# Altium schematic sheet Schematic.SchDoc
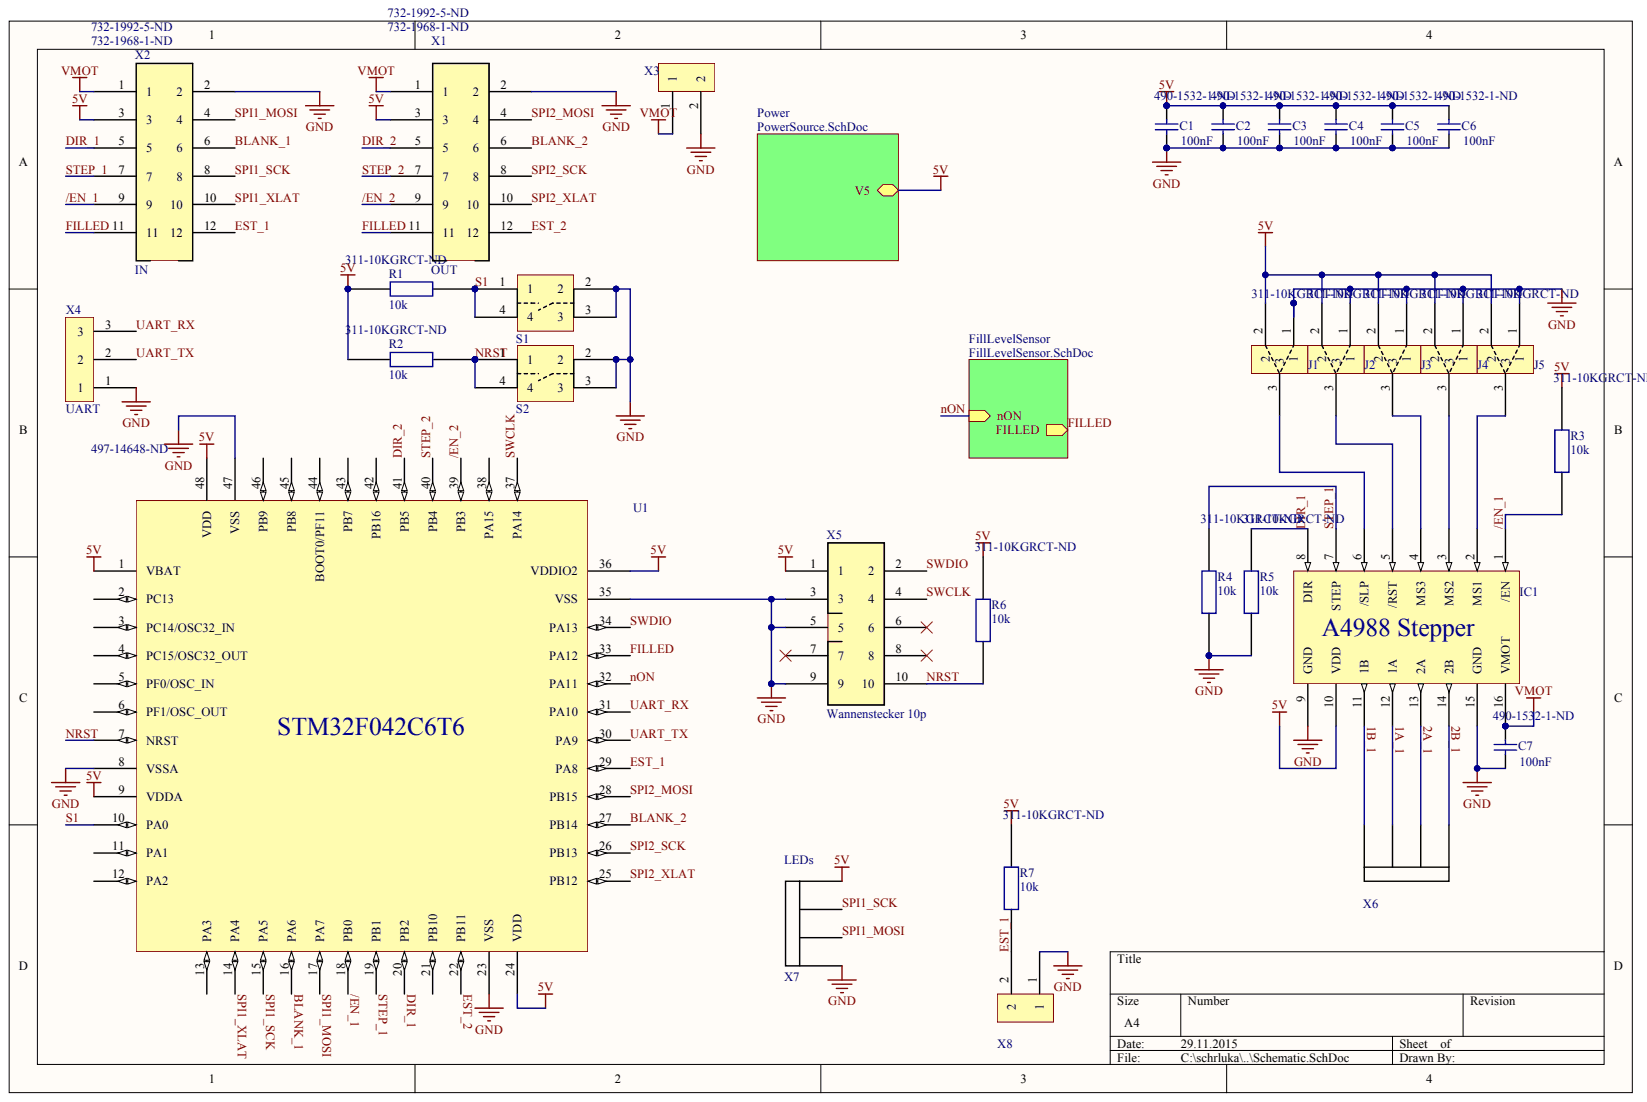
[diagram p0: Schematic.SchDoc]

## Parts
C1  value 100nF  Supplier Part Number 1: 490-1532-1-ND  (hidden comment: Cap)
C2  value 100nF  Supplier Part Number 1: 490-1532-1-ND  (hidden comment: Cap)
C3  value 100nF  Supplier Part Number 1: 490-1532-1-ND  (hidden comment: Cap)
C4  value 100nF  Supplier Part Number 1: 490-1532-1-ND  (hidden comment: Cap)
C5  value 100nF  Supplier Part Number 1: 490-1532-1-ND  (hidden comment: Cap)
C6  value 100nF  Supplier Part Number 1: 490-1532-1-ND  (hidden comment: Cap)
C7  value 100nF  Supplier Part Number 1: 490-1532-1-ND  (hidden comment: Cap)
IC1  A4988 Stepper
J1  Supplier Part Number 1: 311-10KGRCT-ND  (hidden comment: VCC to GND Jumper)
J2  Supplier Part Number 1: 311-10KGRCT-ND  (hidden comment: VCC to GND Jumper)
J3  Supplier Part Number 1: 311-10KGRCT-ND  (hidden comment: VCC to GND Jumper)
J4  Supplier Part Number 1: 311-10KGRCT-ND  (hidden comment: VCC to GND Jumper)
J5  Supplier Part Number 1: 311-10KGRCT-ND  (hidden comment: VCC to GND Jumper)
R1  Supplier Part Number 1: 311-10KGRCT-ND  (hidden comment: 10k)
R2  Supplier Part Number 1: 311-10KGRCT-ND  (hidden comment: 10k)
R3  Supplier Part Number 1: 311-10KGRCT-ND  (hidden comment: 10k)
R4  Supplier Part Number 1: 311-10KGRCT-ND  (hidden comment: 10k)
R5  Supplier Part Number 1: 311-10KGRCT-ND  (hidden comment: 10k)
R6  Supplier Part Number 1: 311-10KGRCT-ND  (hidden comment: 10k)
R7  Supplier Part Number 1: 311-10KGRCT-ND  (hidden comment: 10k)
S1  Taster  (value not drawn)
S2  Taster  (value not drawn)
U1  STM32F042C6T6  Supplier Part Number 1: 497-14648-ND
X1  OUT
X2  IN  Supplier Part Number 1: 732-1968-1-ND
X3  2p Connector  (value not drawn)
X4  UART
X5  Wannenstecker 10p
X6  4p Connector  (value not drawn)
X7  LEDs
X8  2p Connector  (value not drawn)

## Nets
5V: C1.2 C2.2 C3.2 C4.2 C5.2 C6.2 IC1.10(VDD) J1.2 J2.2 J3.2 J4.2 J5.2 R1.2 R2.2 R3.2 R6.2 R7.2 U1.1(VBAT) U1.9(VDDA) U1.24(VDD) U1.36(VDDIO2) U1.48(VDD) X1.3 X2.3 X5.1 X7.4
GND: C1.1 C2.1 C3.1 C4.1 C5.1 C6.1 C7.1 IC1.9(GND) IC1.15(GND) J1.1 J2.1 J3.1 J4.1 J5.1 R4.1 R5.1 S1.2 S1.3 S2.2 S2.3 U1.8(VSSA) U1.23(VSS) U1.35(VSS) U1.47(VSS) X1.2 X2.2 X3.2 X4.1 X5.3 X5.5 X5.9 X7.1 X8.1
VMOT: C7.2 IC1.16(VMOT) X1.1 X2.1 X3.1
1A_1: IC1.12(1A) X6.3
1B_1: IC1.11(1B) X6.4
2A_1: IC1.13(2A) X6.2
2B_1: IC1.14(2B) X6.1
/EN_1: IC1.1(/EN) R3.1 U1.18(PB0) X2.9
/EN_2: U1.39(PB3) X1.9
BLANK_1: U1.16(PA6) X2.6
BLANK_2: U1.27(PB14) X1.6
DIR_1: IC1.8(DIR) R5.2 U1.20(PB2) X2.5
DIR_2: U1.41(PB5) X1.5
EST_1: R7.1 U1.29(PA8) X2.12 X8.2
EST_2: U1.22(PB11) X1.12
FILLED: U1.33(PA12) X1.11 X2.11
NON: U1.32(PA11)
NRST: R2.1 R6.1 S2.1 S2.4 U1.7(NRST) X5.10
S1: R1.1 S1.1 S1.4 U1.10(PA0)
SPI1_MOSI: U1.17(PA7) X2.4 X7.2
SPI1_SCK: U1.15(PA5) X2.8 X7.3
SPI1_XLAT: U1.14(PA4) X2.10
SPI2_MOSI: U1.28(PB15) X1.4
SPI2_SCK: U1.26(PB13) X1.8
SPI2_XLAT: U1.25(PB12) X1.10
STEP_1: IC1.7(STEP) R4.2 U1.19(PB1) X2.7
STEP_2: U1.40(PB4) X1.7
SWCLK: U1.37(PA14) X5.4
SWDIO: U1.34(PA13) X5.2
UART_RX: U1.31(PA10) X4.3
UART_TX: U1.30(PA9) X4.2
NetIC1_2: IC1.2(MS1) J5.3
NetIC1_3: IC1.3(MS2) J4.3
NetIC1_4: IC1.4(MS3) J3.3
NetIC1_5: IC1.5(/RST) J2.3
NetIC1_6: IC1.6(/SLP) J1.3
NetU1_2: U1.2(PC13)
NetU1_3: U1.3(PC14/OSC32_IN)
NetU1_4: U1.4(PC15/OSC32_OUT)
NetU1_5: U1.5(PF0/OSC_IN)
NetU1_6: U1.6(PF1/OSC_OUT)
NetU1_11: U1.11(PA1)
NetU1_12: U1.12(PA2)
NetU1_13: U1.13(PA3)
NetU1_21: U1.21(PB10)
NetU1_38: U1.38(PA15)
NetU1_42: U1.42(PB16)
NetU1_43: U1.43(PB7)
NetU1_44: U1.44(BOOT0/PF11)
NetU1_45: U1.45(PB8)
NetU1_46: U1.46(PB9)
NetX5_6: X5.6
NetX5_7: X5.7
NetX5_8: X5.8

## Library data of the parts
C1-C7 IC1 J1-J5 R1-R7 S1 S2 U1 X1-X8 = (no symbol name)
  C1-C7: Published: 8-Jun-2000; LatestRevisionDate: 17-Jul-2002; LatestRevisionNote: Re-released for DXP Platform.; PackageReference: RAD-0.3; PackageDescription: Radial Cap, Thru-Hole; 2 Leads; 0.3 in Pin Spacing; Supplier 1: Digi-Key; Publisher: Altium Limited
  J1-J5 U1: Supplier 1: Digi-Key
  R1-R7: Value: 10k; Supplier 1: Digi-Key
  X1: Supplier 1: Digi-Key; Supplier Part Number 1: 732-1968-1-ND; Supplier 2: Digi-Key; Supplier Part Number 2: 732-1992-5-ND
  X2: Supplier 1: Digi-Key; Supplier 2: Digi-Key; Supplier Part Number 2: 732-1992-5-ND
